# Altium schematic sheet TopSheet.SchDoc(TopSheet)
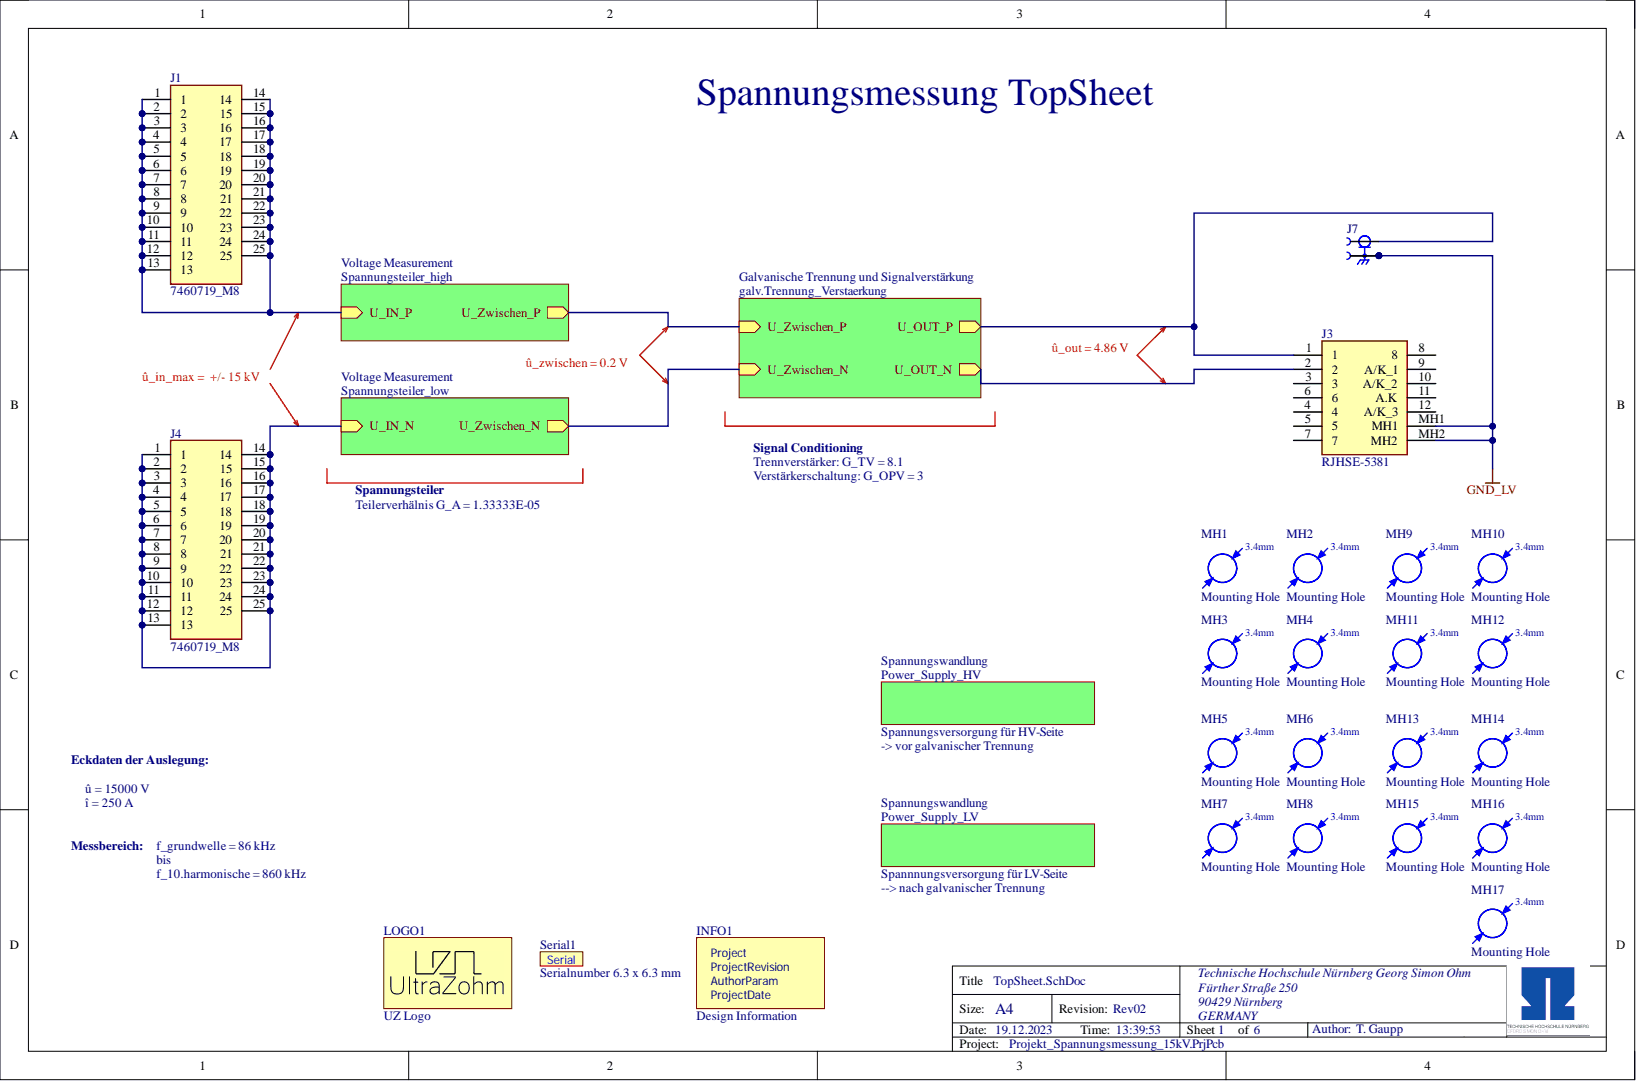
[diagram p0: TopSheet.SchDoc]

## Parts
INFO1  Design Information  [Design_info]  (no BOM)
J1  7460719_M8  [7460719]
J3  RJHSE-5381  [RJHSE5381]
J4  7460719_M8  [7460719]
J7  BNC5-J-P-GN-ST-TH2D_new  [BNC5-J-P-GN-ST-TH2D_new]  (value not drawn)
LOGO1  UZ Logo  value Logo  Component Type: Logo  [UZ_Logo_small]  (no BOM)
MH1  Mounting Hole  [MH_3.4]
MH2  Mounting Hole  [MH_3.4]
MH3  Mounting Hole  [MH_3.4]
MH4  Mounting Hole  [MH_3.4]
MH5  Mounting Hole  [MH_3.4]
MH6  Mounting Hole  [MH_3.4]
MH7  Mounting Hole  [MH_3.4]
MH8  Mounting Hole  [MH_3.4]
MH9  Mounting Hole  [MH_3.4]
MH10  Mounting Hole  [MH_3.4]
MH11  Mounting Hole  [MH_3.4]
MH12  Mounting Hole  [MH_3.4]
MH13  Mounting Hole  [MH_3.4]
MH14  Mounting Hole  [MH_3.4]
MH15  Mounting Hole  [MH_3.4]
MH16  Mounting Hole  [MH_3.4]
MH17  Mounting Hole  [MH_3.4]
Serial1  Serialnumber 6.3 x 6.3 mm  [SERIAL_6.3X6.3MM]  (no BOM)

## Nets
GND_LV: J3.MH1 J3.MH2 J7.S_1 J7.S_2 J7.S_3
NetJ1_1: J1.1 J1.2 J1.3 J1.4 J1.5 J1.6 J1.7 J1.8 J1.9 J1.10 J1.11 J1.12 J1.13 J1.14 J1.15 J1.16 J1.17 J1.18 J1.19 J1.20 J1.21 J1.22 J1.23 J1.24 J1.25
NetJ3_1: J3.1 J7.1
NetJ3_2: J3.2
NetJ3_3: J3.3
NetJ3_4: J3.4
NetJ3_5: J3.5
NetJ3_6: J3.6
NetJ3_7: J3.7
NetJ3_8: J3.8
NetJ3_9: J3.9(A/K_1)
NetJ3_10: J3.10(A/K_2)
NetJ3_11: J3.11(A.K)
NetJ3_12: J3.12(A/K_3)
NetJ4_1: J4.1 J4.2 J4.3 J4.4 J4.5 J4.6 J4.7 J4.8 J4.9 J4.10 J4.11 J4.12 J4.13 J4.14 J4.15 J4.16 J4.17 J4.18 J4.19 J4.20 J4.21 J4.22 J4.23 J4.24 J4.25
U_Zwischen_N:
U_Zwischen_P:

## Library data of the parts
INFO1 = Design Information: Design InformationS; Manufacturer: UltraZohm; Created from: MGremer; Database Table Name: Misc; Component Type: Design Information; ComponentLink1Description: https://docs.ultrazohm.com/; ComponentLink1URL: homepage; Created On: 03.11.2021 09:04:23; Last Updated: 03.11.2021 09:28:34; Library Name: user.DbLib; Live Cycle Status: 1; Manufacturer Part Number: Design Information; PartID: 12; Supplier 1: -; Supplier Part Number 1: -; Type: Design Information; Value: Design Information
J1 J4 = 7460719_M8: Connector; Manufacturer_Name: Wurth Elektronik; Component Kind: Standard; Datasheet Link: https://www.mouser.com/datasheet/2/445/7460719-1723637.pdf; Height: 20.5mm; Library Name: SamacSys.SchLib; Manufacturer_Part_Number: 7460719; Mouser Part Number: 710-7460719; Mouser Price/Stock: https://www.mouser.co.uk/ProductDetail/Wurth-Elektronik/7460719?qs=lykWx4dhCCHoCGzpKhOnqA%3D%3D; Supplier 1: Mouser; Supplier Part Number 1: 710-7460719
J3 = RJHSE-5381: CAT5, RJ45 Connector, 1 port; Manufacturer: Amphenol; Created from: DHufnagel; Database Table Name: Interface - Ethernet; Component Kind: Standard; Component Type: RJ45 Connector; ComponentLink1Description: Datasheet; ComponentLink1URL: https://www.mouser.de/datasheet/2/18/1/io_modjack_rjhse-2509079.pdf; ComponentLink2Description: Drawing; ComponentLink2URL: https://cdn.amphenol-cs.com/media/wysiwyg/files/drawing/rjhsex38x.pdf; Created On: 14.06.2022 08:36:24; Device Package: RJ45; Last Updated: 14.06.2022 08:36:42; Library Name: user.DbLib; Live Cycle Status: 1; Manufacturer Part Number: RJHSE-5381; Mounting Type: THT; PartID: 5; Series: RJHSE; Stock number: not stored; Supplier 1: Mouser; Supplier Part Number 1: 523-RJHSE-5381; Type: RJ45 Connector
J7 = BNC5-J-P-GN-ST-TH2D_new: BNC Connector; Component Kind: Standard; Library Name: SamacSys.SchLib; Supplier 1: Mouser; Supplier Part Number 1: 200-BNC5JPGNSTTH2D
LOGO1 = Logo small: Manufacturer: UltraZohm; Created from: MGremer; Database Table Name: Misc; ComponentLink1Description: https://docs.ultrazohm.com/; ComponentLink1URL: homepage; Created On: 03.11.2021 09:04:23; Description: UZ Logo; Last Updated: 03.11.2021 09:05:50; Library Name: user.DbLib; Live Cycle Status: 1; Manufacturer Part Number: Logo small; PartID: 10; Supplier 1: -; Supplier Part Number 1: -; Type: Logo; Created: Jan2021_Liegmann
MH1-MH17 = Mounting Hole: Created from: MHlatky; Database Table Name: Misc; Component Kind: Standard; Component Type: Mounting Hole; ComponentLink1Description: Datasheet; Created On: 26.01.2021 09:19:51; Description: Mounting Hole; Device Package: -; Last Updated: 21.03.2022 23:05:12; Library Name: user.DbLib; Live Cycle Status: 1; Manufacturer: Mounting Hole; Manufacturer Part Number: Mounting Hole; PartID: 16; Stock number: not stored; Supplier 1: Mounting Hole; Supplier Part Number 1: Mounting Hole; Type: Mounting Hole; Value: Mounting Hole
Serial1 = Serial Number: Manufacturer: UltraZohm; Created from: MGremer; Database Table Name: Misc; Value: REV; Component Type: Serial Number; ComponentLink1Description: https://docs.ultrazohm.com/; ComponentLink1URL: homepage; Created On: 03.11.2021 09:04:23; Description: Serial Number; Last Updated: 03.11.2021 09:28:34; Library Name: user.DbLib; Live Cycle Status: 1; Manufacturer Part Number: Serial Number; PartID: 11; Supplier 1: -; Supplier Part Number 1: -; Type: Serial Number; Created: 2018_09_18_AGeiger
